# Altium schematic sheet MP_Section.SchDoc(MP_Section)
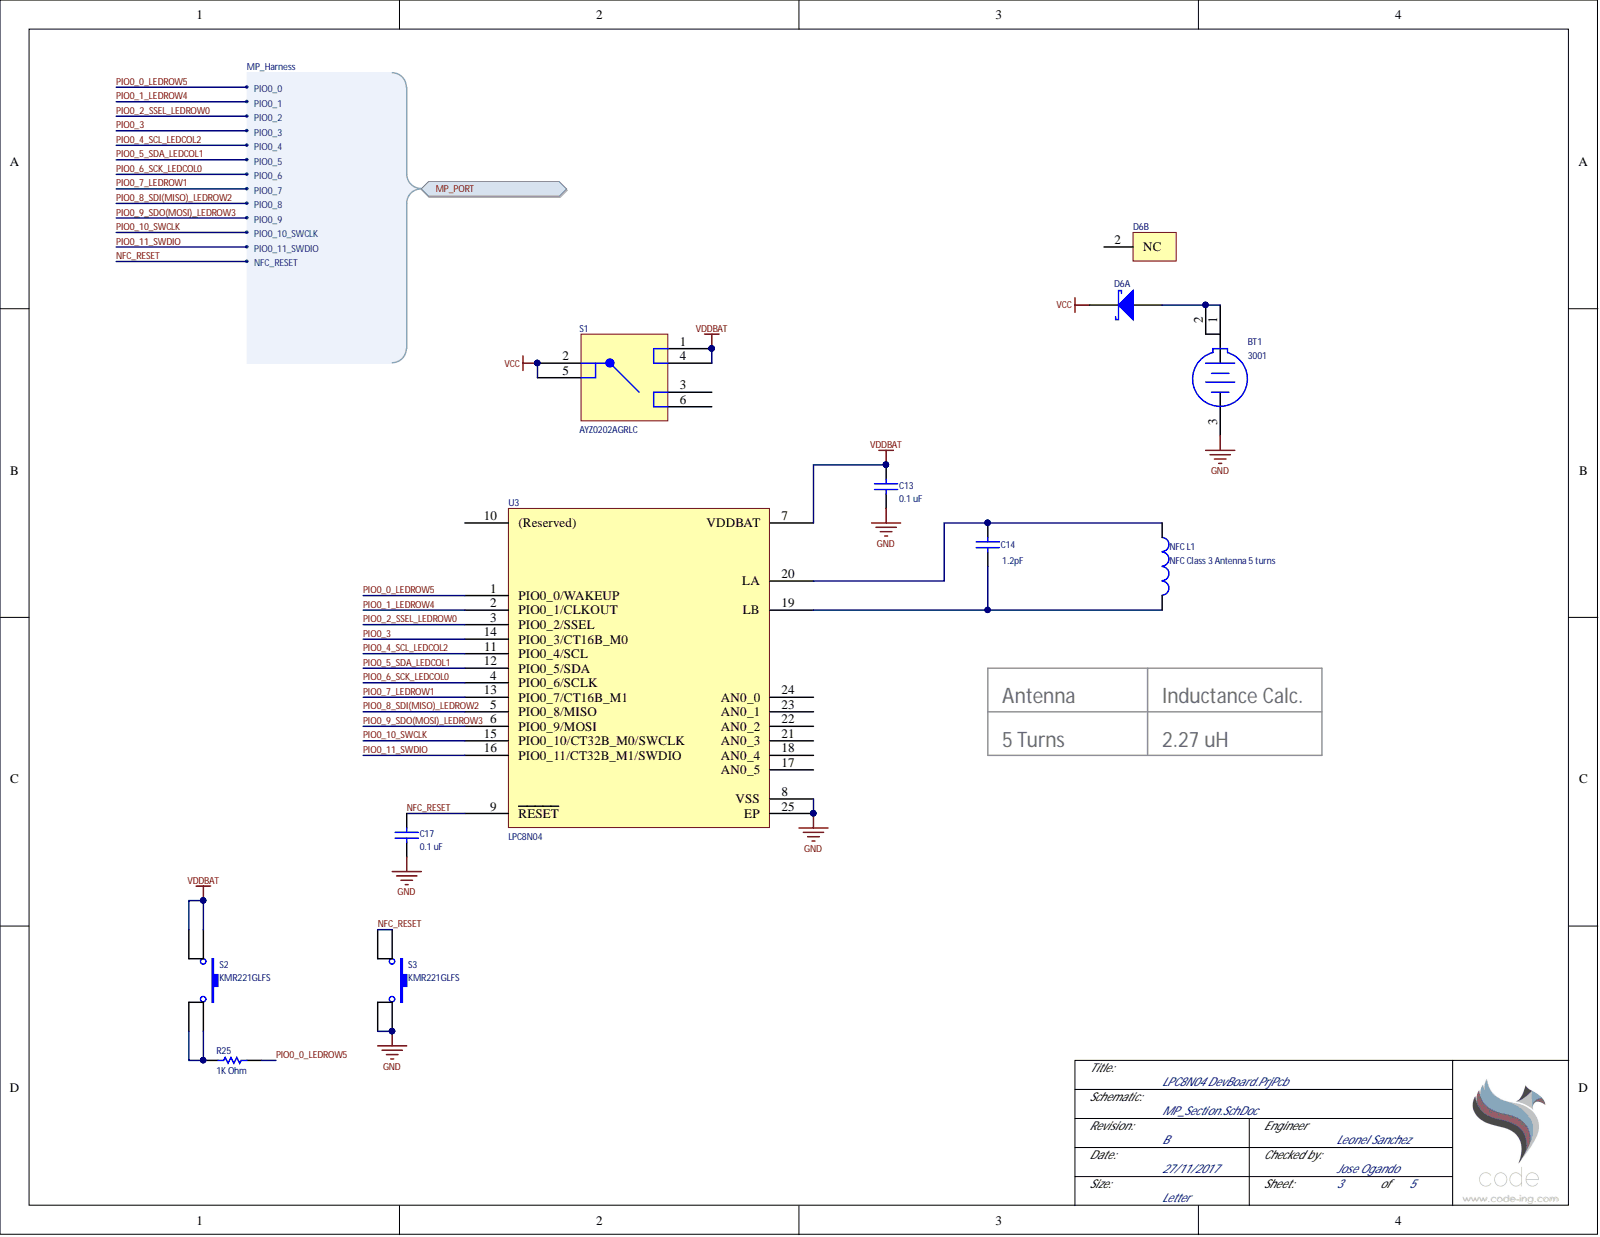
[diagram p0: MP_Section.SchDoc]

## Parts
BT1  3001  [KSTN-3001_V]
C13  Capacitance: 0.1 uF  [CAPC1005]  (hidden comment: CC0402KRX7R7BB104)
C14  value 1.2pF  [CAPC0603(1608)100_L]  (hidden comment: CAP 1.2pF 50V 0603(1608))
C17  Capacitance: 0.1 uF  [CAPC1005]  (hidden comment: CC0402KRX7R7BB104)
D6  BAT54  [NXP-SOT23-3_V]  (value not drawn)  (parts A,B)
NFC_L1  NFC Class 3 Antenna 5 turns  [NFC NXP Class 3 Antenna 5 Turns]
R25  value 1K Ohm  [RESC0402(1005)_N]  (hidden comment: 1K 5% 0402(1005))
S1  AYZ0202AGRLC  [AYZ0202AGRLC]
S2  KMR221GLFS  [KMR221GLFS]
S3  KMR221GLFS  [KMR221GLFS]
U3  LPC8N04  [HVQFN24]

## Ports
MP_PORT, MP_PORT.NFC_RESET, MP_PORT.PIO0_0, MP_PORT.PIO0_1, MP_PORT.PIO0_2, MP_PORT.PIO0_3, MP_PORT.PIO0_4, MP_PORT.PIO0_5, MP_PORT.PIO0_6, MP_PORT.PIO0_7, MP_PORT.PIO0_8, MP_PORT.PIO0_9, MP_PORT.PIO0_10_SWCLK, MP_PORT.PIO0_11_SWDIO

## Nets
GND: BT1.3 C13.1 C17.1 S3.2 S3.3 U3.8(VSS) U3.25(EP)
VCC: D6.3 S1.2 S1.5
VDDBAT: C13.2 S1.1 S1.4 S2.1 S2.4 U3.7(VDDBAT)
NFC_RESET: C17.2 S3.1 S3.4 U3.9(RESET) ; port MP_PORT
PIO0_0_LEDROW5: R25.2 U3.1 ; port MP_PORT
PIO0_1_LEDROW4: U3.2 ; port MP_PORT
PIO0_2_SSEL_LEDROW0: U3.3 ; port MP_PORT
PIO0_3: U3.14 ; port MP_PORT
PIO0_4_SCL_LEDCOL2: U3.11 ; port MP_PORT
PIO0_5_SDA_LEDCOL1: U3.12 ; port MP_PORT
PIO0_6_SCK_LEDCOL0: U3.4 ; port MP_PORT
PIO0_7_LEDROW1: U3.13 ; port MP_PORT
PIO0_8_SDI(MISO)_LEDROW2: U3.5 ; port MP_PORT
PIO0_9_SDO(MOSI)_LEDROW3: U3.6 ; port MP_PORT
PIO0_10_SWCLK: U3.15 ; port MP_PORT
PIO0_11_SWDIO: U3.16 ; port MP_PORT
NetBT1_1: BT1.1 BT1.2 D6.1
NetC14_1: C14.1 NFC_L1.2 U3.19(LB)
NetC14_2: C14.2 NFC_L1.1 U3.20(LA)
NetR25_1: R25.1 S2.2 S2.3

Not connected: D6 2(NC); S1 3 6; U3 10((Reserved)) 17(AN0_5) 18(AN0_4) 21(AN0_3) 22(AN0_2) 23(AN0_1) 24(AN0_0)

## Library data of the parts
BT1 = CMP-2000-05463-1: Holder Battery Coin, 12 mm, 2-Pin THD, RoHS; Manufacturer: Keystone; Package Reference: 3001; Package Description: 2-Pin Through Hole Device, Body 13.21 x 12.07 mm; Mounting Technology: ThroughHole; Manufacturer URL: http://www.keyelco.com/; Library Name: Altium Content Vault; Datasheet Version: Rev. H, 09/2006; Datasheet URL: https://datasheet.ciiva.com/3/3001-3954.pdf; Component Kind: Standard
C13 C17 = CMP-0001-00074-1: Capacitor, Yageo, Ceramic, 0.1uF 10% 16V 0402 (1005) X7R; Polarity: Non-Polarized; Tolerance: 10 %; Technology: Ceramic; Status: Production; Rated Voltage: 16 V; Mounting Technology: Surface Mount; Library Name: Altium Content Vault; Dielectric: X7R; Component Kind: Standard; ClassName: Board Template Device; Category: Capacitor; Publisher: Altium
C14 = CMP-1035-00069-1: CAP 1.2pF 50V ±0.25pF 0603 (1608 Metric) Thickness 1mm SMD; Tolerance: Â±0.25pF; Case-Metric: 1608; Case-EIA: 0603; Technology: SMT; Rated Voltage: 50 V; Max Thickness: 1 mm; Library Name: Altium Content Vault; Component Kind: Standard
D6 = CMP-0417-00004-1: Schottky Barrier Diode, 30 V, 2 uA, -55 to 150 degC, 3-Pin SOT23, RoHS, Tape and Reel; Manufacturer: NXP Semiconductors; VR (V): 30; VF (mV): 800; Trr (ns): 5; Rth(j-a) (K/W): 500; IR (uA): 2; Cd (pF): 10; Temperature Range: -55 to 150 degC; RoHS: TRUE; PartNumber: BAT54; Packing: Tape and Reel; PackageReference: SOT23-3; PackageDescription: 3-Pin Plastic Surface-mounted Package, Body 1.3 x 2.9 mm, Pitch 0.95 mm; Mounting Technology: Surface Mount; Library Name: Altium Content Vault; DatasheetVersion: Rev. 5; ComponentLink2URL: http://www.nxp.com/documents/data_sheet/BAT54_SER.pdf; ComponentLink2Description: Datasheet; ComponentLink1URL: http://www.nxp.com/; ComponentLink1Description: Manufacturer URL; Component Kind: Standard
NFC_L1 = Class 3 NXP NFC Antenna 5 turns: NFC Class 3 Antenna - 5 turns; Manufacturer Part #: NFC NXP Antenna Class 3; Library Name: RFID Antenna.IntLib; Creation Date: 4-Sept-2017; Component Kind: Net Tie; Created by: Jose
R25 = CMP-1011-00074-1: 1K 0.063W 5% 0402 (1005 Metric)  SMD; Case-Metric: 1005; Case-EIA: 0402; Tolerance: 5 %; Technology: SMT; Power: 0.063 W; Library Name: Altium Content Vault; Component Kind: Standard
S1 = AYZ0202AGRLC: SWITCH SLIDE DPDT 100MA 12V; Manufacturer: C&K Components; Manufacturer part #: AYZ0202AGRLC; Library Name: Slide Switches.IntLib; Creation Date: 31-Aug-2017; Component Kind: Standard; Created by: Jose
S2 S3 = KMR221GLFS: SWITCH TACTILE SPST-NO 0.05A 32V; Manufacturer: C&K; Manufacturer part #: KMR221GLFS; Library Name: Tactile.IntLib; Creation Date: 06-Jul-2017; Component Kind: Standard; Created by: eteofilo
U3 = LPC8N04: NXP LPC8N04 NFC Cortex M0+; Manufacturer: NXP; Creation Date: 31-Aug-2017; Component Kind: Standard; Library Name: RFID, RF Access, Monitoring ICs.IntLib; Created by: Jose
